# Altium schematic sheet connectors.SchDoc
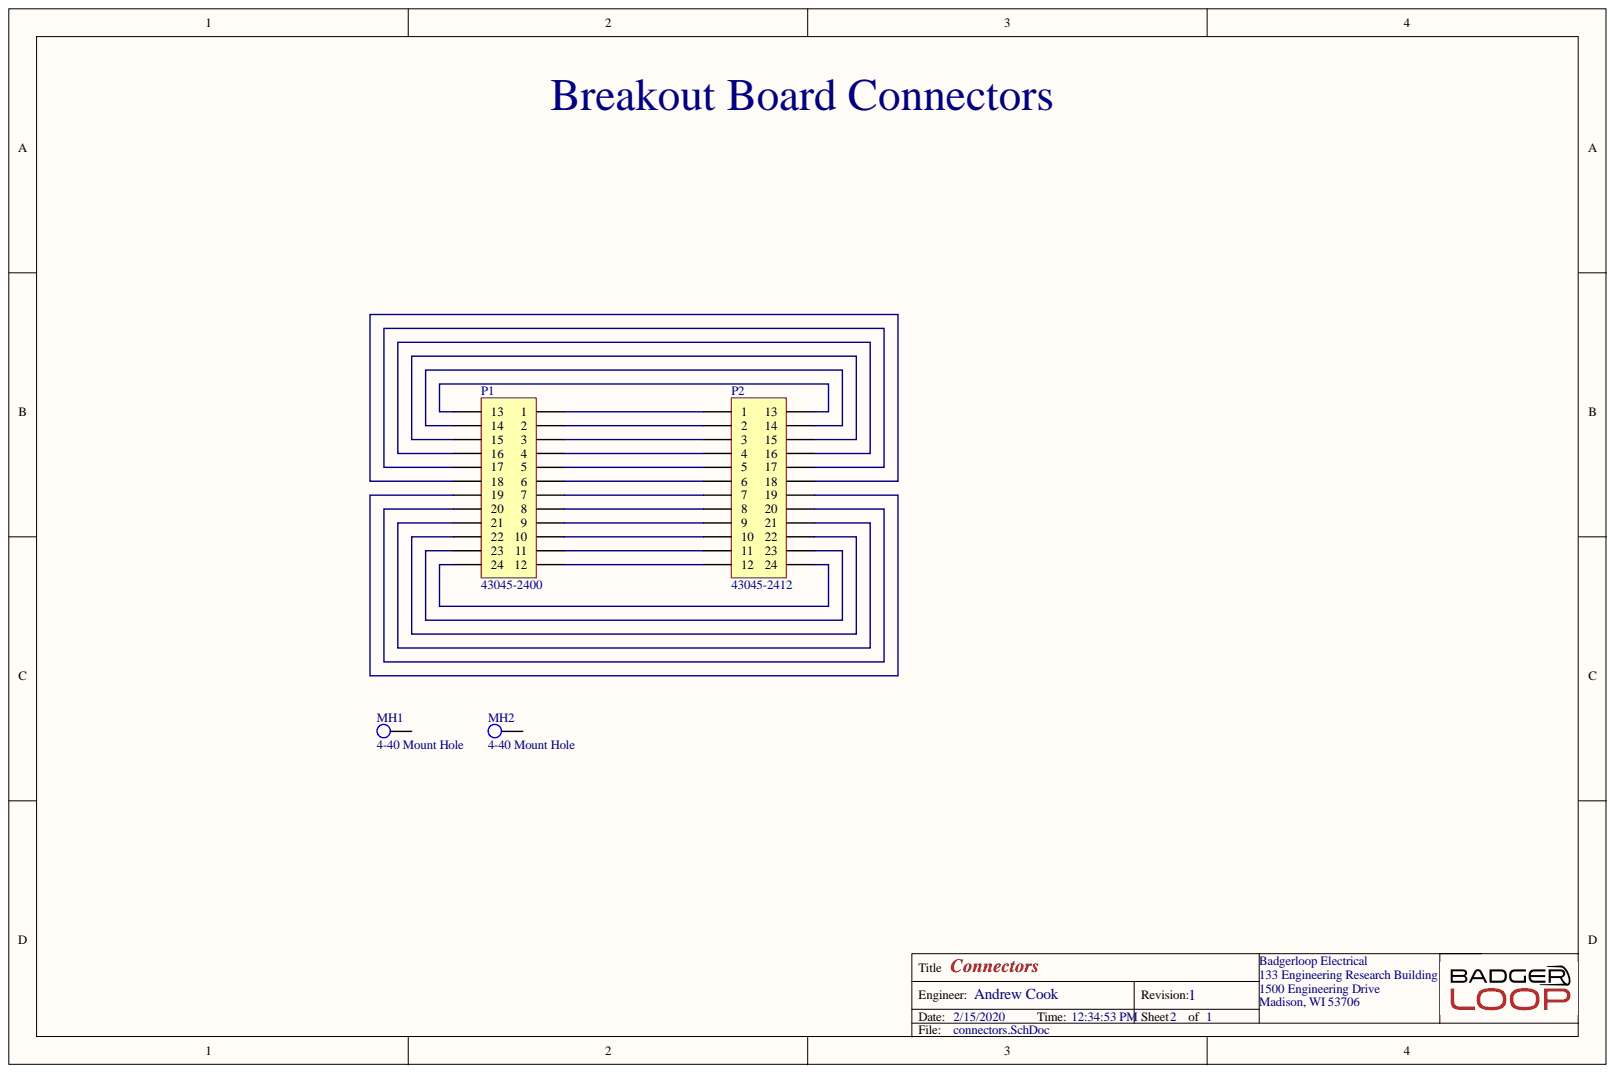
[diagram p0: connectors.SchDoc]

## Parts
MH1  4-40 Mount Hole  [MH]
MH2  4-40 Mount Hole  [MH]
P1  43045-2400  [430452400]
P2  43045-2412  [0430452412]

## Nets
NetP1_1: P1.1 P2.1
NetP1_2: P1.2 P2.2
NetP1_3: P1.3 P2.3
NetP1_4: P1.4 P2.4
NetP1_5: P1.5 P2.5
NetP1_6: P1.6 P2.6
NetP1_7: P1.7 P2.7
NetP1_8: P1.8 P2.8
NetP1_9: P1.9 P2.9
NetP1_10: P1.10 P2.10
NetP1_11: P1.11 P2.11
NetP1_12: P1.12 P2.12
NetP1_13: P1.13 P2.13
NetP1_14: P1.14 P2.14
NetP1_15: P1.15 P2.15
NetP1_16: P1.16 P2.16
NetP1_17: P1.17 P2.17
NetP1_18: P1.18 P2.18
NetP1_19: P1.19 P2.19
NetP1_20: P1.20 P2.20
NetP1_21: P1.21 P2.21
NetP1_22: P1.22 P2.22
NetP1_23: P1.23 P2.23
NetP1_24: P1.24 P2.24

Not connected: MH1 46; MH2 46

## Library data of the parts
P1 = 43045-2400: Molex MICRO-FIT 3.0 Series, Series Number 43045, 3mm Pitch 24 Way 2 Row Right Angle PCB Header, Solder Termination, 5A; Approvals: UL,CSA,TUV; Contact Resistance: 10mR; Gender: Male; Glow Wire Compliant: Compliant; Insulation Resistance: 1GR; Max Voltage Rating (DC): 250.25kV; Number of Circuits: 24; Operating Supply Voltage: 250V; Voltage Rating: 600V; Color: Black; Component Kind: Standard; ComponentLink1Description: https://datasheet.ciiva.com/19461/800104-19461233.pdf?src-supplier=Jameco; ComponentLink1URL: https://datasheet.ciiva.com/19461/800104-19461233.pdf?src-supplier=Jameco; ComponentLink2Description: https://datasheet.ciiva.com/36179/144580430452400-pcb-headers-36179650.pdf?src-supplier=Onlinecomponents.com; ComponentLink2URL: https://datasheet.ciiva.com/36179/144580430452400-pcb-headers-36179650.pdf?src-supplier=Onlinecomponents.com; ComponentLink3Description: https://datasheet.ciiva.com/5156/0430452400-pcb-headers-5156852.pdf?src-supplier=Mouser; ComponentLink3URL: https://datasheet.ciiva.com/5156/0430452400-pcb-headers-5156852.pdf?src-supplier=Mouser; ComponentLink4Description: https://datasheet.ciiva.com/11748/0900766b80d6135b-11748645.pdf?src-supplier=RSComponents; ComponentLink4URL: https://datasheet.ciiva.com/11748/0900766b80d6135b-11748645.pdf?src-supplier=RSComponents; ComponentLink5Description: https://datasheet.ciiva.com/7839/1596512-7839674.pdf?src-supplier=Element14; ComponentLink5URL: https://datasheet.ciiva.com/7839/1596512-7839674.pdf?src-supplier=Element14; ComponentLink6Description: https://datasheet.ciiva.com/16657/0430452400-pcb-headers-16657916.pdf?src-supplier=Avnet+Europe; ComponentLink6URL: https://datasheet.ciiva.com/16657/0430452400-pcb-headers-16657916.pdf?src-supplier=Avnet+Europe; ComponentLink7Description: https://datasheet.ciiva.com/14155/04402310-14155214.pdf?src-supplier=Distrelec; ComponentLink7URL: https://datasheet.ciiva.com/14155/04402310-14155214.pdf?src-supplier=Distrelec; Contact Material: Brass,Tin; Contact Plating: Tin; Current Rating: 5A; Depth: 12.2mm; Flammability Rating: UL94 V-0; Halogen Free: Low Halogen; Height: 8.77mm; Housing Color: Black; Housing Material: Thermoplastic; Lead Free: Lead Free; Lead Pitch: 3mm; Length: 40.15mm; Library Name: connector.SchLib; Manufacturer: Molex; Manufacturer Part Number: 43045-2400; Max Current Rating: 5A; Max Operating Temperature: 105°C; Min Operating Temperature: -40°C; Mount: Through Hole; Number of Contacts: 24; Number of Poles: 24; Number of Positions: 24; Number of Rows: 2; Orientation: Right Angle; Package Quantity: 576; Packaging: Tray; Pitch: 3mm; Pricing 1: 1=6.20000, 10=5.95100, 100=4.95920, 576=4.21531, 1152=3.59542 (USD); Radiation Hardening: No; REACH SVHC: Unknown; RoHS: Yes; Row Spacing: 3mm; Stock 1: 970; Supplier 1: Digi-Key; Supplier Part Number 1: WM4728-ND; Termination: Solder; Voltage Rating (AC): 250V; Voltage Rating (DC): 250V; Working Voltage: 250V
P2 = 43045-2412: HEADER, VERTICAL, 24WAY; Series:Micro-Fit 3.0; Pitch Spacing:3mm; Contact Termination Type:Through Hole; No. of Contacts:24; No. of Rows:2; Connector Mounting:PCB; Gender:Plug; Contact Material:Brass; Contact Plating:Tin; ;RoHS Compliant: Yes; Manufacturer: Molex; Approvals: UL,CSA,TUV; Connector Type: Plug,Socket; Contact Resistance: 10mR; Current Rating: 5A; Gender: Male; Insulation Resistance: 1GR; Max Voltage Rating (DC): 250.25kV; Number of Circuits: 24; Operating Supply Voltage: 250V; Pitch: 3mm; Voltage Rating: 600V; Color: Black; Component Kind: Standard; ComponentLink1Description: http://www.farnell.com/datasheets/1786158.pdf?src-supplier=Element14; ComponentLink1URL: http://www.farnell.com/datasheets/1786158.pdf?src-supplier=Element14; ComponentLink2Description: https://www.alliedelec.com/m/d/28e7c516ce872cc01c31d58871c770af.pdf?src-supplier=Allied+Electronics; ComponentLink2URL: https://www.alliedelec.com/m/d/28e7c516ce872cc01c31d58871c770af.pdf?src-supplier=Allied+Electronics; ComponentLink3Description: http://www.jameco.com/Jameco/Products/ProdDS/883907.pdf?src-supplier=Jameco; ComponentLink3URL: http://www.jameco.com/Jameco/Products/ProdDS/883907.pdf?src-supplier=Jameco; ComponentLink4Description: http://www.mouser.com/ds/2/276/0430452412_PCB_HEADERS-168381.pdf?src-supplier=Mouser; ComponentLink4URL: http://www.mouser.com/ds/2/276/0430452412_PCB_HEADERS-168381.pdf?src-supplier=Mouser; ComponentLink5Description: http://www.molex.com/webdocs/datasheets/pdf/en-us/0430452412_PCB_HEADERS.pdf?src-supplier=Avnet; ComponentLink5URL: http://www.molex.com/webdocs/datasheets/pdf/en-us/0430452412_PCB_HEADERS.pdf?src-supplier=Avnet; ComponentLink6Description: http://uk.rs-online.com/webdocs/13c3/0900766b813c3b1e.pdf?src-supplier=RSComponents; ComponentLink6URL: http://uk.rs-online.com/webdocs/13c3/0900766b813c3b1e.pdf?src-supplier=RSComponents; ComponentLink7Description: http://www.molex.com/webdocs/datasheets/pdf/en-us/0430452412_PCB_HEADERS.pdf?src-supplier=Avnet+Europe; ComponentLink7URL: http://www.molex.com/webdocs/datasheets/pdf/en-us/0430452412_PCB_HEADERS.pdf?src-supplier=Avnet+Europe; Contact Material: Brass,Tin; Contact Plating: Tin; Depth: 8.77mm; Flammability Rating: UL94 V-0; Glow Wire Compliant: Compliant; Halogen Free: Low Halogen; Height: 9.91mm; Housing Color: Black; Housing Material: Thermoplastic; Lead Free: Lead Free; Lead Pitch: 3mm; Length: 40.15mm; Library Name: connector.SchLib; Material: Polyester; Max Current Rating: 5A; Max Operating Temperature: 105°C; Min Operating Temperature: -40°C; Mount: Through Hole; Number of Contacts: 24; Number of Poles: 24; Number of Positions: 24; Number of Rows: 2; Orientation: Vertical; Package Quantity: 576; Packaging: Tray; Pricing 1: 1=4.89, 10=4.693, 100=3.9112, 576=3.32451, 1152=2.83562 (USD); Radiation Hardening: No; REACH SVHC: Unknown; Row Spacing: 3mm; Stock 1: 772; Supplier 1: Digi-Key; Supplier Part Number 1: WM4716-ND; Termination: Solder; Voltage Rating (AC): 250V; Voltage Rating (DC): 250V; Working Voltage: 250V
MH1 MH2 = 4-40 Mount Hole: Component Kind: Standard; Library Name: misc.SchLib
